# Altium schematic sheet 12_fit_YK.SchDoc(12_fit_YK)
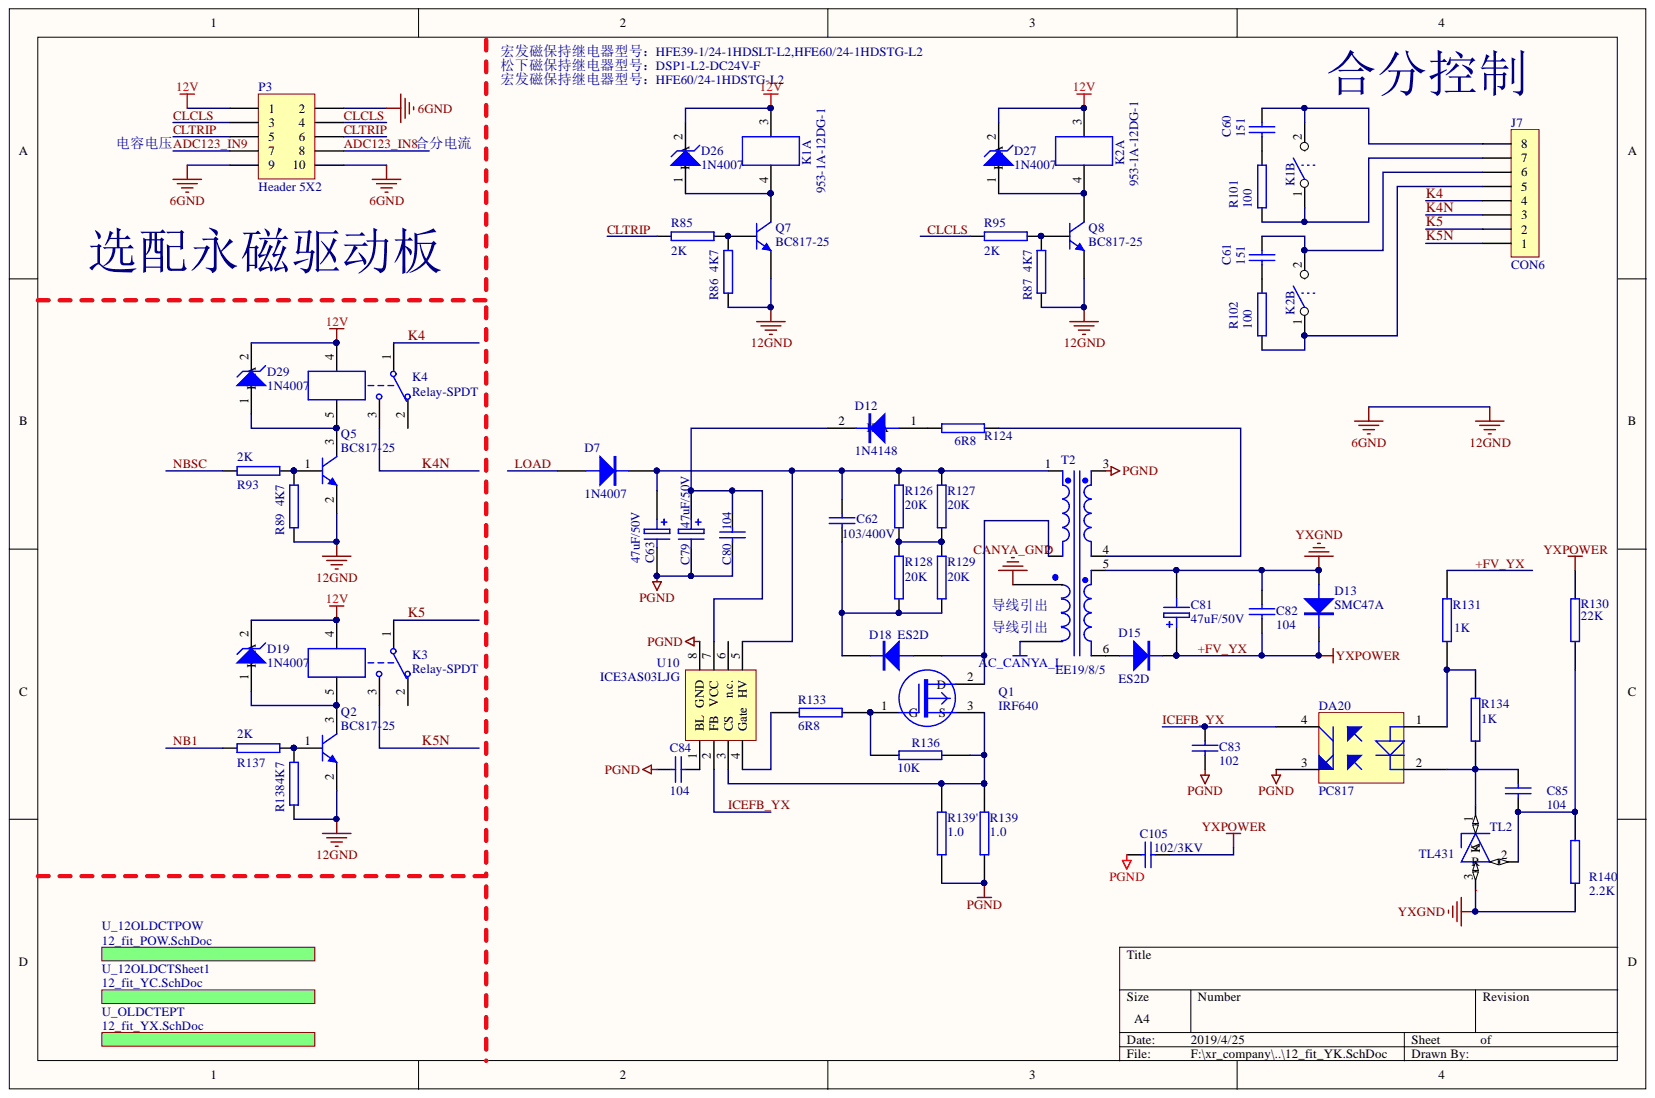
[diagram p0: 12_fit_YK.SchDoc]

## Parts
C60  151  [CAP_HV_10]
C61  151  [CAP_HV_10]
C62  103/400V  [CAP_HV_8]
C63  47uF/50V  [47u/50V]
C79  47uF/50V  [47u/50V]
C80  104  [C1206]
C81  47uF/50V  [47u/50V]
C82  104  [CBB104]
C83  102  [C1206]
C84  104  [C1206]
C85  104  [CBB104]
C105  102/3KV  [CAP_HV_8]
D7  1N4007  [DO-214AA]
D12  1N4148  [SMT_4148]
D13  SMC47A  [DO-214AB]
D15  ES2D  [DO-214AA]
D18  ES2D  [DO-214AA]
D19  1N4007  [DO-214AC]
D26  1N4007  [DO-214AC]
D27  1N4007  [DO-214AC]
D29  1N4007  [DO-214AC]
DA20  PC817  [dip4]
J7  CON6  [XR_POWER5.08_8_弯]
K1  953-1A-12DG-1  [953-1A-12DG-1]  (parts A,B)
K2  953-1A-12DG-1  [953-1A-12DG-1]  (parts A,B)
K3  Relay-SPDT  [JQX-115FA]
K4  Relay-SPDT  [JQX-115FA]
P3  Header 5X2  [HDR2X5]
Q1  IRF640  [TO220-IRF540]
Q2  BC817-25  [SOT-23]
Q5  BC817-25  [SOT-23]
Q7  BC817-25  [SOT-23]
Q8  BC817-25  [SOT-23]
R85  2K  [R1206]
R86  4K7  [R1206]
R87  4K7  [R1206]
R89  4K7  [R1206]
R93  2K  [R1206]
R95  2K  [R1206]
R101  100  [res-l5]
R102  100  [res-l5]
R124  6R8  [R1206]
R126  20K  [R1206]
R127  20K  [R1206]
R128  20K  [R1206]
R129  20K  [R1206]
R130  22K  [R1206]
R131  1K  [R1206]
R133  6R8  [R1206]
R134  1K  [R1206]
R136  10K  [R1206]
R137  2K  [R1206]
R138  4K7  [R1206]  (value not drawn)
R139  1.0  [R2512]
R139'  1.0  [R2512]
R140  2.2K  [R1206]
T2  EE19/8/5  [EE19/8/5]
TL2  TL431  [SOT-23]
U10  ICE3AS03LJG  [SO8]

## Nets
6GND: P3.2 P3.9 P3.10 Q2.2 Q5.2 Q7.2 Q8.2 R86.1 R87.1 R89.1 R138.1
12V: D19.2 D26.2 D27.2 D29.2 K1.3 K2.3 K3.4 K4.4 P3.1
PGND: C63.2 C79.2 C80.1 C83.1 C84.1 C105.1 DA20.3 R139.1 R139'.1 T2.3 U10.8(GND)
YXGND: C81.2 C82.2 D13.1 R140.1 T2.5 TL2.3
+FV_YX: C81.1 C82.1 C105.2 D13.2 D15.2 R130.2 R131.2
ADC123_IN8: P3.8
ADC123_IN9: P3.7
CLCLS: P3.3 P3.4 R95.1
CLTRIP: P3.5 P3.6 R85.1
ICEFB_YX: C83.2 DA20.4 U10.2(FB)
K4: J7.4 K4.1
K4N: J7.3 K4.3
K5: J7.2 K3.1
K5N: J7.1 K3.3
LOAD: D7.1
NB1: R137.1
NBSC: R93.1
NetC60_1: C60.1 R101.1
NetC60_2: C60.2 J7.8 K1.2
NetC61_1: C61.1 J7.6 K2.2
NetC61_2: C61.2 R102.1
NetC62_1: C62.1 D18.2 R128.1 R129.1
NetC62_2: C62.2 C63.1 D7.2 R126.2 R127.2 T2.1 U10.5(HV)
NetC79_1: C79.1 C80.2 D12.2 U10.7(VCC)
NetC84_2: C84.2 U10.1(BL)
NetC85_1: C85.1 DA20.2 R134.1 TL2.1
NetC85_2: C85.2 R130.1 R140.2 TL2.2
NetD12_1: D12.1 R124.1
NetD15_1: D15.1 T2.6
NetD18_1: D18.1 Q1.2 T2.2
NetD19_1: D19.1 K3.5 Q2.3
NetD26_1: D26.1 K1.4 Q7.3
NetD27_1: D27.1 K2.4 Q8.3
NetD29_1: D29.1 K4.5 Q5.3
NetDA20_1: DA20.1 R131.1 R134.2
NetJ7_5: J7.5 K2.1 R102.2
NetJ7_7: J7.7 K1.1 R101.2
NetQ1_1: Q1.1 R133.1 R136.2
NetQ1_3: Q1.3 R136.1 R139.2 R139'.2 U10.3(CS)
NetQ2_1: Q2.1 R137.2 R138.2
NetQ5_1: Q5.1 R89.2 R93.2
NetQ7_1: Q7.1 R85.2 R86.2
NetQ8_1: Q8.1 R87.2 R95.2
NetR124_2: R124.2 T2.4
NetR126_1: R126.1 R127.1 R128.2 R129.2
NetR133_2: R133.2 U10.4(Gate)
AC_CANYA_L:
CANYA_GND:

Not connected: K3 2; K4 2; U10 6(n.c.)

## Library data of the parts
C60-C62 C83 = CAP: Component Kind: Standard
C63 C79 C81 = ELECTRO1: Electrolytic Capacitor; Component Kind: Standard
C80 C82 C84 C85 C105 = CAP: Capacitor; Component Kind: Standard
D7 D12 D13 D15 D18 = DIODE: Description: Diode; Component Kind: Standard
D19 D26 D27 D29 = D Zener: Zener Diode; Published: 8-Jun-2000; PackageReference: DIODE-0.7; PackageDescription: Diode, Thru-Hole; 2 Leads; 0.7 in Pin Spacing; Library Name: Miscellaneous Devices.IntLib; LatestRevisionNote: Re-released for DXP Platform.; LatestRevisionDate: 17-Jul-2002; Component Kind: Standard; Publisher: Altium Limited
DA20 = TLP521-1: Component Kind: Standard
J7 = CON8: Connector; Component Kind: Standard
K1 K2 = RLY-STY1: RELAY; Component Kind: Standard
K3 K4 = Relay-SPDT: Single-Pole Dual-Throw Relay; Simulation: SPDTRELAY; Published: 24-Mar-1999; PackageReference: MODULE5B; Library Name: Miscellaneous Devices.SchLib; LatestRevisionNote: Re-released for DXP Platform.; LatestRevisionDate: 17-Jul-2002; Component Kind: Standard; Publisher: Altium Limited
P3 = Header 5X2: Header, 5-Pin, Dual row; Signal Integrity: Connector; Library Name: Miscellaneous Connectors.IntLib; LatestRevisionNote: Re-released for DXP Platform.; LatestRevisionDate: 17-Jul-2002; Component Kind: Standard; Publisher: Altium Limited
Q1 = MOSFET-P1: Component Kind: Standard
Q2 Q5 Q7 Q8 = SOT-23 2SC3356-T1B-A    S/YS档: NPN; SuppliersPartNumber: C9659; Supplier: LC; Package: SOT-23; Library Name: Miscellaneous Devices LC.IntLib; ComponentLink1URL: http://www.szlcsc.com/product/details_10189.html; ComponentLink1Description: 供应商链接; Component Kind: Standard
R85-R87 R89 R93 R95 R101 R102 R124 R126-R131 R133 R134 R136-R139 R139' R140 = RES2: Component Kind: Standard
T2 = Trans3 Ideal: Three-winding Transformer (Ideal); Published: 25-Jan-2001; PackageReference: TRF_6; PackageDescription: Transformer; 6 Leads; Library Name: Miscellaneous Devices.IntLib; LatestRevisionNote: Re-released for DXP Platform.; LatestRevisionDate: 17-Jul-2002; Component Kind: Standard; Publisher: Altium Limited
TL2 = TL431: Component Kind: Standard
U10 = ICE3AS03LJG: Component Kind: Standard
